# Altium schematic sheet ESP_RELAYS_BOX_6CH_LATCH.SchDoc
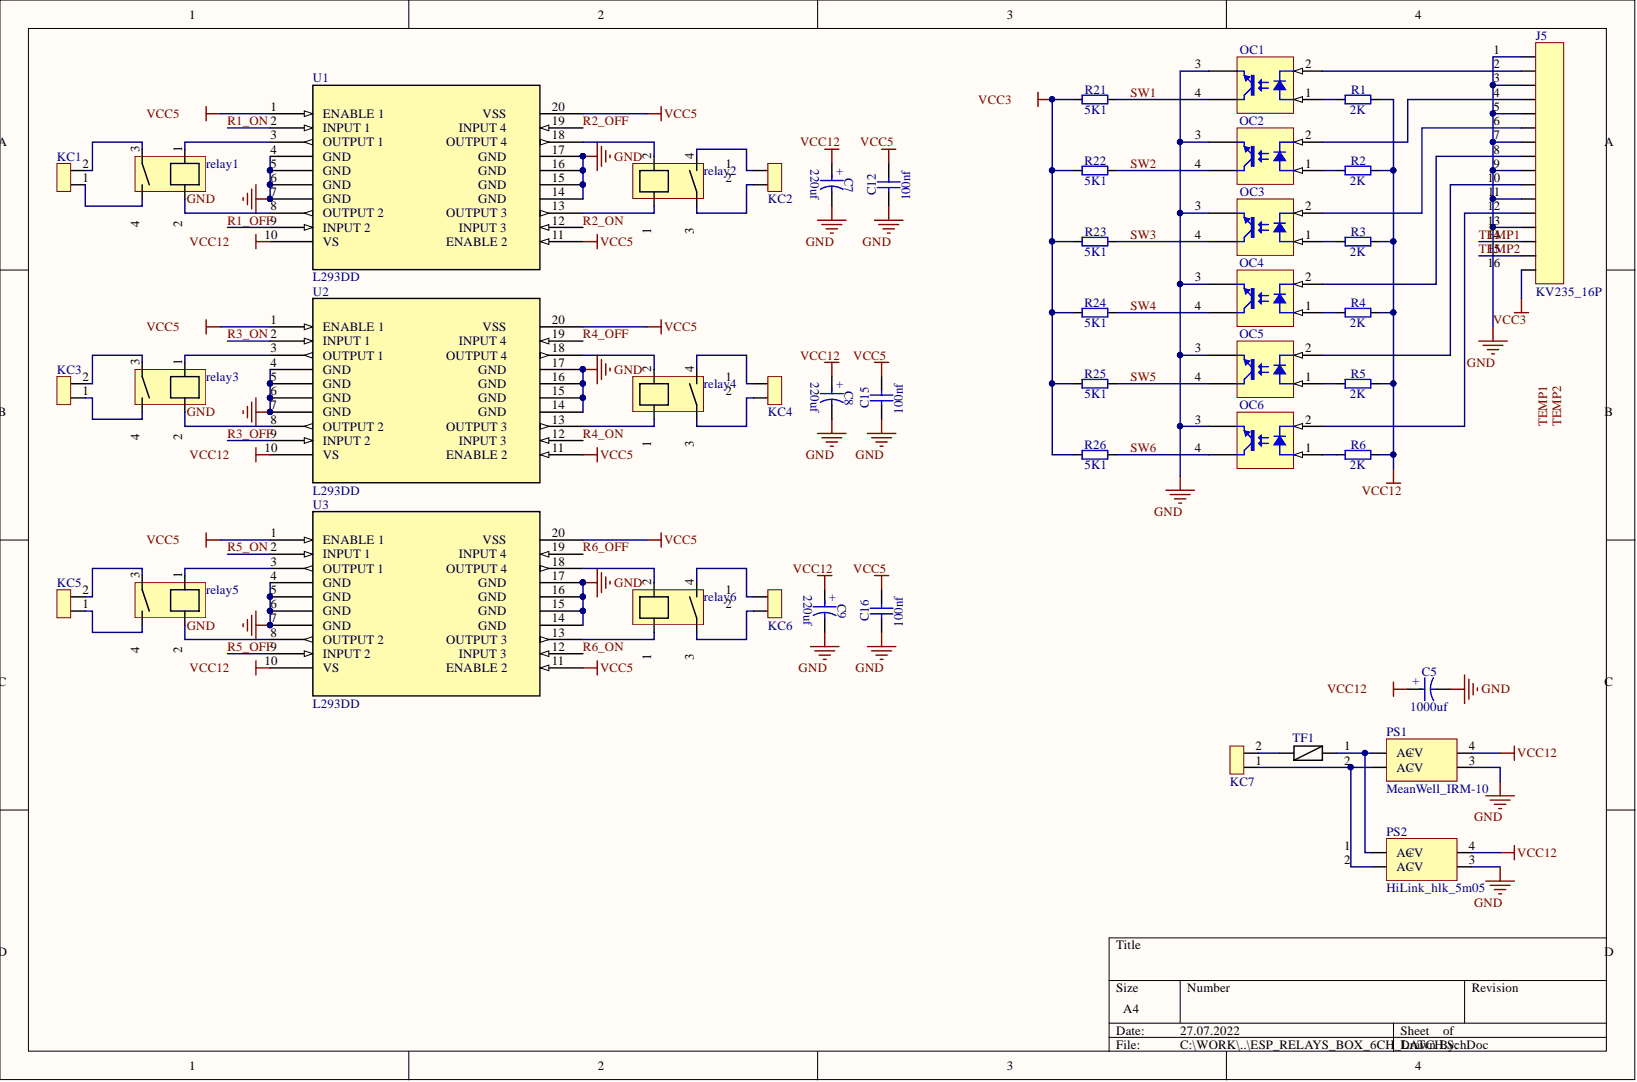
[diagram p0: ESP_RELAYS_BOX_6CH_LATCH.SchDoc]

## Parts
C5  1000uf  [CAP_SOLID_8x14H]
C7  220uf  [CAP_SOLID_5x9]
C8  220uf  [CAP_SOLID_5x9]
C9  220uf  [CAP_SOLID_5x9]
C12  100nf  [CAP_0603]
C15  100nf  [CAP_0603]
C16  100nf  [CAP_0603]
J5  KV235_16P  [KV235_16P]
KC1  KF7620_2P  [KF7620_2P]  (value not drawn)
KC2  KF7620_2P  [KF7620_2P]  (value not drawn)
KC3  KF7620_2P  [KF7620_2P]  (value not drawn)
KC4  KF7620_2P  [KF7620_2P]  (value not drawn)
KC5  KF7620_2P  [KF7620_2P]  (value not drawn)
KC6  KF7620_2P  [KF7620_2P]  (value not drawn)
KC7  KF7620_2P  [KF7620_2P]  (value not drawn)
OC1  SFH6156-4  [SOIC254P977X406-4N]  (value not drawn)
OC2  SFH6156-4  [SOIC254P977X406-4N]  (value not drawn)
OC3  SFH6156-4  [SOIC254P977X406-4N]  (value not drawn)
OC4  SFH6156-4  [SOIC254P977X406-4N]  (value not drawn)
OC5  SFH6156-4  [SOIC254P977X406-4N]  (value not drawn)
OC6  SFH6156-4  [SOIC254P977X406-4N]  (value not drawn)
PS1  MeanWell_IRM-10  [MeanWell_IRM-10]
PS2  HiLink_hlk_5m05  [HILINK_HLK_5M05]
R1  2K  [RES_1206]
R2  2K  [RES_1206]
R3  2K  [RES_1206]
R4  2K  [RES_1206]
R5  2K  [RES_1206]
R6  2K  [RES_1206]
R21  5K1  [RES_0603]
R22  5K1  [RES_0603]
R23  5K1  [RES_0603]
R24  5K1  [RES_0603]
R25  5K1  [RES_0603]
R26  5K1  [RES_0603]
relay1  HFE10-2-12-HT-L1  [HFE10_SINGLE]  (value not drawn)
relay2  HFE10-2-12-HT-L1  [HFE10_SINGLE]  (value not drawn)
relay3  HFE10-2-12-HT-L1  [HFE10_SINGLE]  (value not drawn)
relay4  HFE10-2-12-HT-L1  [HFE10_SINGLE]  (value not drawn)
relay5  HFE10-2-12-HT-L1  [HFE10_SINGLE]  (value not drawn)
relay6  HFE10-2-12-HT-L1  [HFE10_SINGLE]  (value not drawn)
TF1  Fuse_2A  [T2A_FUSE]  (value not drawn)
U1  L293DD  [SO-20_STM]
U2  L293DD  [SO-20_STM]
U3  L293DD  [SO-20_STM]

## Nets
GND: C5.2 C7.2 C8.2 C9.2 C12.1 C15.1 C16.1 J5.1 J5.3 J5.5 J5.7 J5.9 J5.11 J5.13 OC1.3 OC2.3 OC3.3 OC4.3 OC5.3 OC6.3 PS1.3 PS2.3 U1.4(GND) U1.5(GND) U1.6(GND) U1.7(GND) U1.14 U1.15 U1.16 U1.17 U2.4(GND) U2.5(GND) U2.6(GND) U2.7(GND) U2.14 U2.15 U2.16 U2.17 U3.4(GND) U3.5(GND) U3.6(GND) U3.7(GND) U3.14 U3.15 U3.16 U3.17
VCC3: J5.16 R21.1 R22.1 R23.1 R24.1 R25.1 R26.1
VCC5: C12.2 C15.2 C16.2 U1.1(ENABLE_1) U1.11 U1.20 U2.1(ENABLE_1) U2.11 U2.20 U3.1(ENABLE_1) U3.11 U3.20
VCC12: C5.1 C7.1 C8.1 C9.1 PS1.4 PS2.4 R1.2 R2.2 R3.2 R4.2 R5.2 R6.2 U1.10(VS) U2.10(VS) U3.10(VS)
R1_OFF: U1.9(INPUT_2)
R1_ON: U1.2(INPUT_1)
R2_OFF: U1.19
R2_ON: U1.12
R3_OFF: U2.9(INPUT_2)
R3_ON: U2.2(INPUT_1)
R4_OFF: U2.19
R4_ON: U2.12
R5_OFF: U3.9(INPUT_2)
R5_ON: U3.2(INPUT_1)
R6_OFF: U3.19
R6_ON: U3.12
SW1: OC1.4 R21.2
SW2: OC2.4 R22.2
SW3: OC3.4 R23.2
SW4: OC4.4 R24.2
SW5: OC5.4 R25.2
SW6: OC6.4 R26.2
TEMP1: J5.14
TEMP2: J5.15
NetJ5_2: J5.2 OC1.2
NetJ5_4: J5.4 OC2.2
NetJ5_6: J5.6 OC3.2
NetJ5_8: J5.8 OC4.2
NetJ5_10: J5.10 OC5.2
NetJ5_12: J5.12 OC6.2
NetKC1_1: KC1.1 relay1.4
NetKC1_2: KC1.2 relay1.3
NetKC2_1: KC2.1 relay2.4
NetKC2_2: KC2.2 relay2.3
NetKC3_1: KC3.1 relay3.4
NetKC3_2: KC3.2 relay3.3
NetKC4_1: KC4.1 relay4.4
NetKC4_2: KC4.2 relay4.3
NetKC5_1: KC5.1 relay5.4
NetKC5_2: KC5.2 relay5.3
NetKC6_1: KC6.1 relay6.4
NetKC6_2: KC6.2 relay6.3
NetKC7_1: KC7.1 PS1.2(AC) PS2.2(AC)
NetKC7_2: KC7.2 TF1.1
NetOC1_1: OC1.1 R1.1
NetOC2_1: OC2.1 R2.1
NetOC3_1: OC3.1 R3.1
NetOC4_1: OC4.1 R4.1
NetOC5_1: OC5.1 R5.1
NetOC6_1: OC6.1 R6.1
NetPS1_1: PS1.1(AC) PS2.1(AC) TF1.2
Netrelay1_1: relay1.1 U1.3(OUTPUT_1)
Netrelay1_2: relay1.2 U1.8(OUTPUT_2)
Netrelay2_1: relay2.1 U1.13
Netrelay2_2: relay2.2 U1.18
Netrelay3_1: relay3.1 U2.3(OUTPUT_1)
Netrelay3_2: relay3.2 U2.8(OUTPUT_2)
Netrelay4_1: relay4.1 U2.13
Netrelay4_2: relay4.2 U2.18
Netrelay5_1: relay5.1 U3.3(OUTPUT_1)
Netrelay5_2: relay5.2 U3.8(OUTPUT_2)
Netrelay6_1: relay6.1 U3.13
Netrelay6_2: relay6.2 U3.18

## Library data of the parts
C5 = CS_1000UF_10V_8x14: Polarised capacitor; Database Table Name: CAP; GoodsBriefName: RC_C_1000UF_10V; GoodsID: 58669; Voltage: 10V; Код: 19; Case: 8x14H; Component Kind: Standard; Part Number: CS_1000UF_10V_8x14; Pin Count: 2; Value: 1000uf; Library Name: MIPS_COMPONENTS_LIB.DbLib
C7-C9 = CS_220UF_16V_5x9: Polarised capacitor; Database Table Name: CAP; GoodsID: 58669; Voltage: 16V; Код: 39; Case: 5x9H; Component Kind: Standard; Part Number: CS_220UF_16V_5x9; Pin Count: 2; Value: 220uf; Library Name: MIPS_COMPONENTS_LIB.DbLib
C12 C15 C16 = C0603_100NF: Non-polarised capacitor; Database Table Name: CAP; GoodsBriefName: RC_C_0603_100NF_1PCS; GoodsID: 47802; Voltage: 50V; Код: 2; Case: 0603; Component Kind: Standard; Part Number: C0603_100NF; Pin Count: 2; Value: 100nf; Library Name: MIPS_COMPONENTS_LIB.DbLib
J5 = CONN_KV235_16P: 16 pin self-clamping connector; Database Table Name: CONN; Код: 32; Component Kind: Standard; Part Number: CONN_KV235_16P; Pin Count: 32; Value: KV235_16P; Library Name: MIPS_COMPONENTS_LIB.DbLib
KC1-KC7 = CONN_KF7620_2P: Kefa European-style PCB terminal; Database Table Name: CONN; Код: 31; Component Kind: Standard; Part Number: CONN_KF7620_2P; Pin Count: 2; Value: KF7620_2P; Library Name: MIPS_COMPONENTS_LIB.DbLib
OC1-OC6 = PS2501-1: Single Optocoupler; Manufacturer: VISHAY SEMICONDUCTORS; Database Table Name: MISC; Table: Optocouplers; Код: 29; Case: SOP4; ComponentHeight: 4.06mm; Component Kind: Standard; Component Type: Standard; CreateDate: 22.07.2009; Device: SFH6156-4; HelpURL: \\cern.ch\dfs\Applications\Altium\Datasheets\SFH6156-4.pdf; LatestRevisionDate: 22.07.2009; Manufacturer Part Number: SFH6156-4; Mounted: Yes; PackageDescription: SOIC, 2.54mm pitch; 4 pin, 6.65mm W X 4.69mm L, IPC Medium Density; Part Number: PS2501-1; Pin Count: 2; SMD: Yes; Socket: No; Value: PS2501-1; Author: CERN DEM JMW; Library Name: MIPS_COMPONENTS_LIB.DbLib
PS1 = MeanWell_IRM-10-12: 10W AC-DC Power Supply; Database Table Name: MISC; Value: MeanWell IRM-10-12; Код: 33; Case: MeanWell_IRM-10; Component Kind: Standard; Part Number: MeanWell_IRM-10-12; Pin Count: 4; Library Name: MIPS_COMPONENTS_LIB.DbLib
PS2 = MODULE_HLK_PM05_12V: Database Table Name: MISC; Код: 34; Case: 38x23mm; Component Kind: Standard; Part Number: MODULE_HLK_PM05_12V; Pin Count: 4; Value: hlk_pm05_12; Library Name: MIPS_COMPONENTS_LIB.DbLib
R1-R6 = R1206_2K_5%: Resistor; Database Table Name: res; Код: 50; Case: 1206; Component Kind: Standard; Part Number: R1206_2K_5%; Pin Count: 2; Value: 2K; Library Name: MIPS_COMPONENTS_LIB.DbLib
R21-R26 = R0603_5K1_1%: Resistor; Database Table Name: res; GoodsBriefName: RC_R_0603_5-1KOM_5PERCENT; GoodsID: 47801; Код: 9; Case: 0603; Component Kind: Standard; Part Number: R0603_5K1_1%; Pin Count: 2; Value: 5K1; Library Name: MIPS_COMPONENTS_LIB.DbLib
relay1-relay6 = HFE10-2-12-HT-L1: Database Table Name: MISC; Код: 28; Case: HFE10-2-L1; Component Kind: Standard; Part Number: HFE10-2-12-HT-L1; Pin Count: 4; Value: HFE10-2-12-HT-L1; Library Name: MIPS_COMPONENTS_LIB.DbLib
TF1 = ThermalFuse_2A_6x7: Component Kind: Standard; Library Name: trojan1.SchLib
U1-U3 = IC_L293DD: No Description Available; Mfr_Name: STMicroelectronics; Database Table Name: IC; Код: 33; Case: SOP20; Component Kind: Standard; Manufacturer_Part_Number: L293DD; Part Number: IC_L293DD; Pin Count: 20; RefDes: RefDes; Type: DEV; Value: L293DD; Copyright: Copyright (C) 2022 Ultra Librarian. All rights reserved.; Library Name: MIPS_COMPONENTS_LIB.DbLib
